# Altium schematic sheet stm32core3.SchDoc
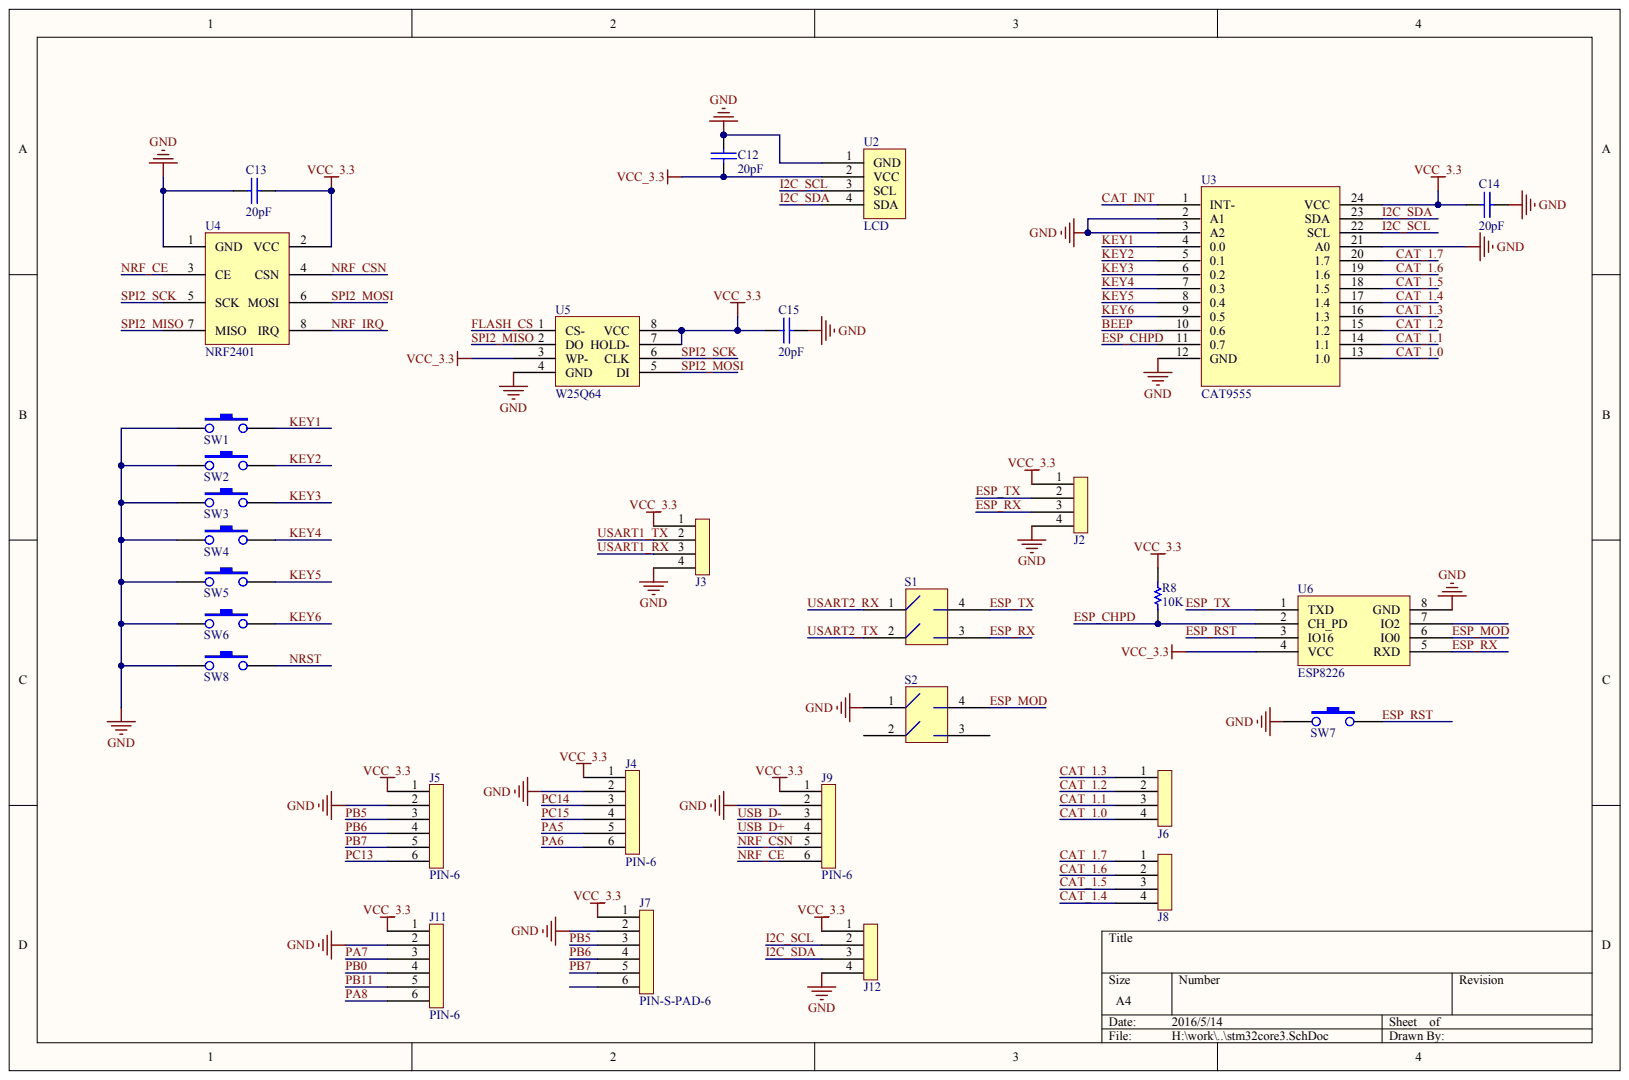
[diagram p0: stm32core3.SchDoc]

## Parts
C12  20pF
C13  20pF
C14  20pF
C15  20pF
J2  DIP-4  (value not drawn)
J3  DIP-4  (value not drawn)
J4  PIN-6
J5  PIN-6
J6  DIP-4  (value not drawn)
J7  PIN-S-PAD-6
J8  DIP-4  (value not drawn)
J9  PIN-6
J11  PIN-6
J12  DIP-4  (value not drawn)
R8  10K
S1  SWITCH-2  (value not drawn)
S2  SWITCH-2  (value not drawn)
SW1  SW
SW2  SW
SW3  SW
SW4  SW
SW5  SW
SW6  SW
SW7  SW
SW8  SW
U2  LCD
U3  CAT9555
U4  NRF2401
U5  W25Q64
U6  ESP8226

## Nets
GND: C12.1 C13.1 C14.2 C15.2 J2.4 J3.4 J4.2 J5.2 J7.2 J9.2 J11.2 J12.4 S2.1 SW1.1 SW2.1 SW3.1 SW4.1 SW5.1 SW6.1 SW7.1 SW8.1 U2.1(GND) U3.2(A1) U3.3(A2) U3.12(GND) U3.21(A0) U4.1(GND) U5.4(GND) U6.8(GND)
VCC_3.3: C12.2 C13.2 C14.1 C15.1 J2.1 J3.1 J4.1 J5.1 J7.1 J9.1 J11.1 J12.1 R8.2 U2.2(VCC) U3.24(VCC) U4.2(VCC) U5.3(WP-) U5.7(HOLD-) U5.8(VCC) U6.4(VCC)
BEEP: U3.10(0.6)
CAT_1.0: J6.4 U3.13(1.0)
CAT_1.1: J6.3 U3.14(1.1)
CAT_1.2: J6.2 U3.15(1.2)
CAT_1.3: J6.1 U3.16(1.3)
CAT_1.4: J8.4 U3.17(1.4)
CAT_1.5: J8.3 U3.18(1.5)
CAT_1.6: J8.2 U3.19(1.6)
CAT_1.7: J8.1 U3.20(1.7)
CAT_INT: U3.1(INT-)
ESP_CHPD: R8.1 U3.11(0.7) U6.2(CH_PD)
ESP_MOD: S2.4 U6.6(IO0)
ESP_RST: SW7.2 U6.3(IO16)
ESP_RX: J2.3 S1.3 U6.5(RXD)
ESP_TX: J2.2 S1.4 U6.1(TXD)
FLASH_CS: U5.1(CS-)
I2C_SCL: J12.2 U2.3(SCL) U3.22(SCL)
I2C_SDA: J12.3 U2.4(SDA) U3.23(SDA)
KEY1: SW1.2 U3.4(0.0)
KEY2: SW2.2 U3.5(0.1)
KEY3: SW3.2 U3.6(0.2)
KEY4: SW4.2 U3.7(0.3)
KEY5: SW5.2 U3.8(0.4)
KEY6: SW6.2 U3.9(0.5)
NRF_CE: J9.6 U4.3(CE)
NRF_CSN: J9.5 U4.4(CSN)
NRF_IRQ: U4.8(IRQ)
NRST: SW8.2
PA5: J4.5
PA6: J4.6
PA7: J11.3
PA8: J11.6
PB0: J11.4
PB5: J5.3 J7.3
PB6: J5.4 J7.4
PB7: J5.5 J7.5
PB11: J11.5
PC13: J5.6
PC14: J4.3
PC15: J4.4
SPI2_MISO: U4.7(MISO) U5.2(DO)
SPI2_MOSI: U4.6(MOSI) U5.5(DI)
SPI2_SCK: U4.5(SCK) U5.6(CLK)
USART1_RX: J3.3
USART1_TX: J3.2
USART2_RX: S1.1
USART2_TX: S1.2
USB_D+: J9.4
USB_D-: J9.3

Not connected: J7 6; S2 2 3; U6 7(IO2)
